# Altium schematic sheet Strommessung.SchDoc
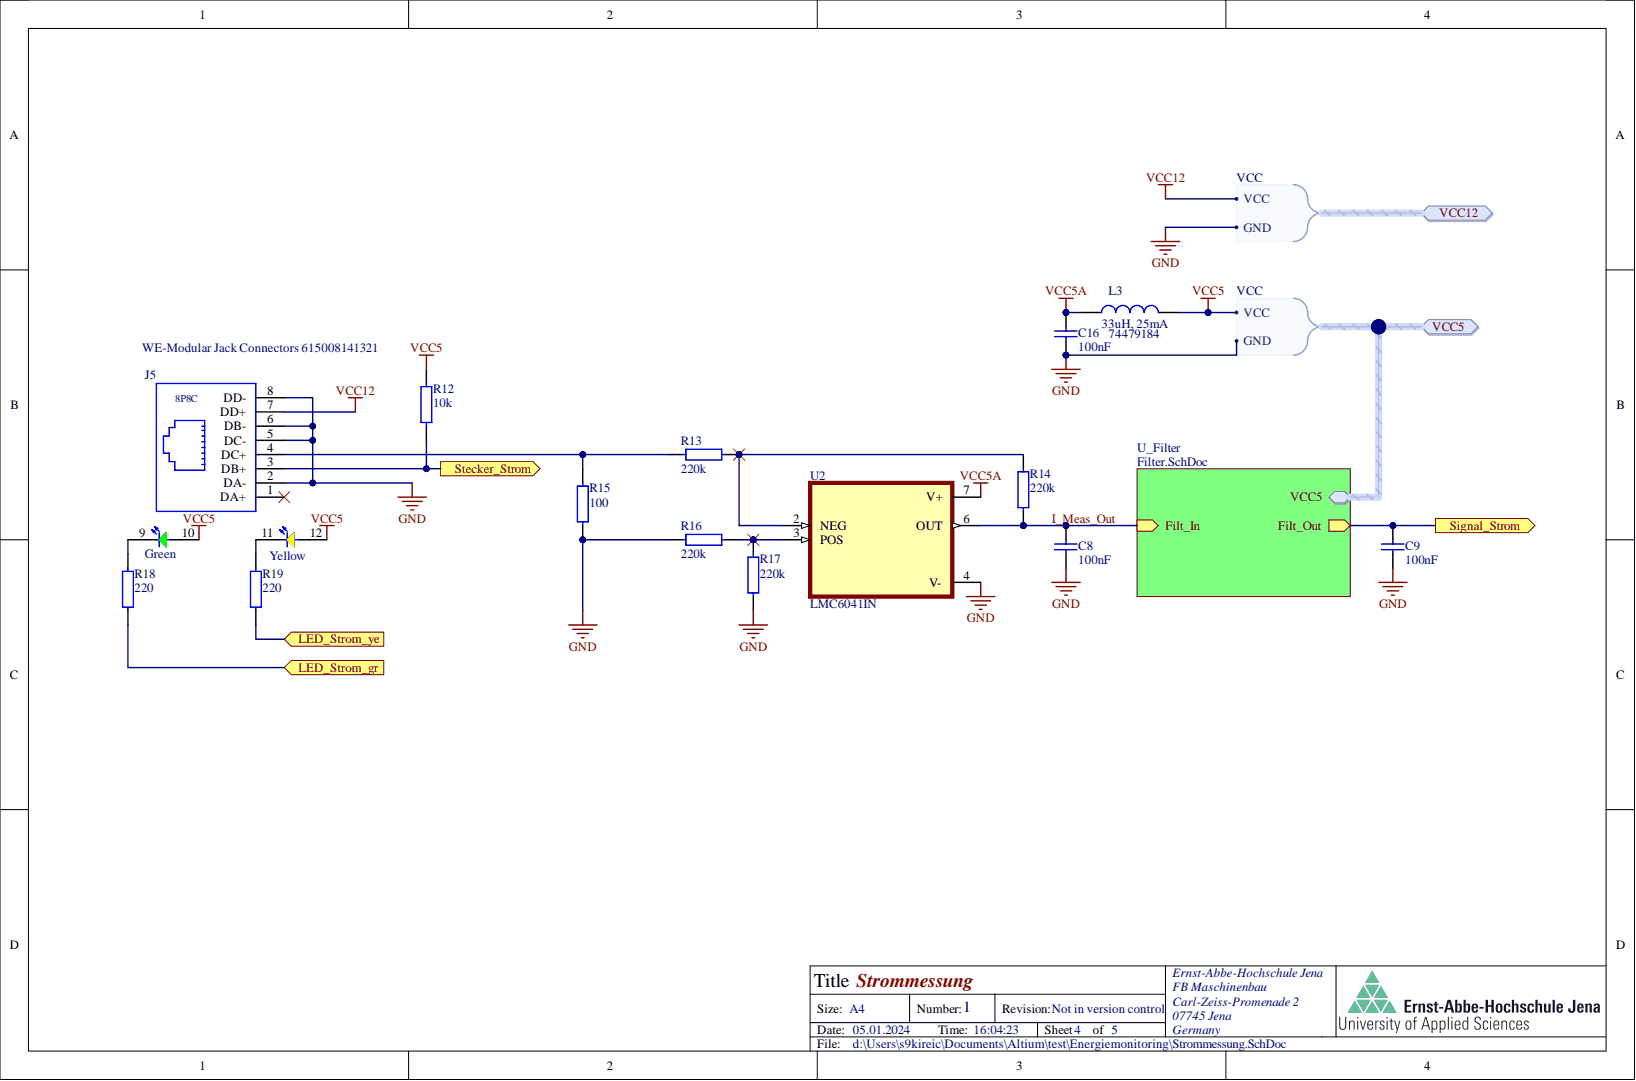
[diagram p0: Strommessung.SchDoc]

## Parts
C8  100nF  [SMD-0805]
C9  100nF  [SMD-0805]
C16  100nF  [SMD-0805]
J5  WE-Modular Jack Connectors 615008141321  [615008141321]
L3  33uH, 25mA  Rated Current: 25mA  [WE-MI_1206]
R12  10k  [R-SMD-0805]
R13  220k  [R-SMD-0805]
R14  220k  [R-SMD-0805]
R15  100  [R-SMD-0805]
R16  220k  [R-SMD-0805]
R17  220k  [R-SMD-0805]
R18  220  [R-SMD-0805]
R19  220  [R-SMD-0805]
U2  LMC6041IN  [DIP794W45P254L959H508Q8]

## Ports
LED_Strom_gr, LED_Strom_ye, Signal_Strom, Stecker_Strom, VCC5, VCC5.GND, VCC5.VCC, VCC12, VCC12.GND, VCC12.VCC

## Nets
VCC5A: C16.2 L3.1 U2.7(V+)
GND: C8.1 C9.1 C16.1 J5.2(DA-) J5.5(DC-) J5.6(DB-) J5.8(DD-) R15.1 R16.1 R17.1 U2.4(V-) ; port VCC12, port VCC5
I_Meas_Out: C8.2 R14.1 U2.6(OUT)
LED_Strom_gr: R18.1 ; port LED_Strom_gr
LED_Strom_ye: R19.1 ; port LED_Strom_ye
Signal_Strom: C9.2 ; port Signal_Strom
Stecker_Strom: J5.3(DB+) R12.1 ; port Stecker_Strom
VCC5: J5.10 J5.12 L3.2 R12.2 ; port VCC5
VCC12: J5.7(DD+) ; port VCC12
NetJ5_1: J5.1(DA+)
NetJ5_4: J5.4(DC+) R13.1 R15.2
NetJ5_9: J5.9 R18.2
NetJ5_11: J5.11 R19.2
NetR23_2: R13.2 R14.2 U2.2(NEG)
NetR26_2: R16.2 R17.2 U2.3(POS)

## Library data of the parts
C8 C9 C16 = C: Kondensator; Component Kind: Standard; Library Name: SMD.IntLib; Value: 100nF
J5 = WE-Modular Jack Connectors 615008141321: WR-COM Modular Jack Horizontal Shielded 1x1 TH LED 2x Bipolar Green/Yellow Green=Fw EMI  fingers  8P8C Tab Up; Manufacturer: W¨¹rth Elektronik eiSos GmbH; ComponentLink1 Description: Manufacturer; ComponentLink2 Description: Datasheet; NoPins: 12; Component Kind: Standard; ComponentLink1URL: http://www.we-online.de; ComponentLink2URL: http://katalog.we-online.de/em/datasheet/615008141321.pdf; Created Date: 10/22/2014; Library Name: WE_WR-MJ_Modular Jack Connectors_rev15b.IntLib; partNo: 615008141321; Created by: Karrer Zheng
L3 = 74479184: WE-MI SMT Multilayer Inductor, size 1206, 33uH, 25mA; Manufacturer: Wurth Elektronik; DC Resistance max.: 0.9Ohm; DC Resistance typ.: 0.65Ohm; Q-Factor: 35; Component Kind: Standard; ComponentLink1Description: Manufacturer; ComponentLink1URL: http://www.we-online.de; ComponentLink2Description: Datasheet; ComponentLink2URL: https://www.we-online.com/catalog/datasheet/74479184.pdf; Inductance: 33uH; Manufacturer Part Number: 74479184; Mounting Technology: SMT; Operating Temperature: -55 to +125degC; Packaging: Tape and Reel; Published Date: April 2020; Size: 1206; Library Name: Altium_WE_eiSos_WE-MI.IntLib
R12-R19 = R: Widerstand; Component Kind: Standard; Library Name: SMD.IntLib
  R12: Value: 10k
  R13 R14 R16 R17: Value: 220k
  R15: Value: 100
  R18 R19: Value: 220
U2 = LMC6041IN: CMOS Single Micropower Operational Amplifier 8-PDIP -40 to 85; MF: Texas Instruments; MP: LMC6041IN/NOPB; Availability: In Stock; Check_prices: https://www.snapeda.com/parts/LMC6041IN/NOPB/Texas+Instruments/view-part/?ref=eda; Component Kind: Standard; Library Name: LMC6041IN.IntLib; Package: PDIP-8 Texas; Price: None; Purchase-URL: https://pricing.snapeda.com/search/part/LMC6041IN/NOPB/?ref=eda; SnapEDA_Link: https://www.snapeda.com/parts/LMC6041IN/NOPB/Texas+Instruments/view-part/?ref=snap
